# Altium schematic sheet Mosfet.SchDoc(Mosfet)
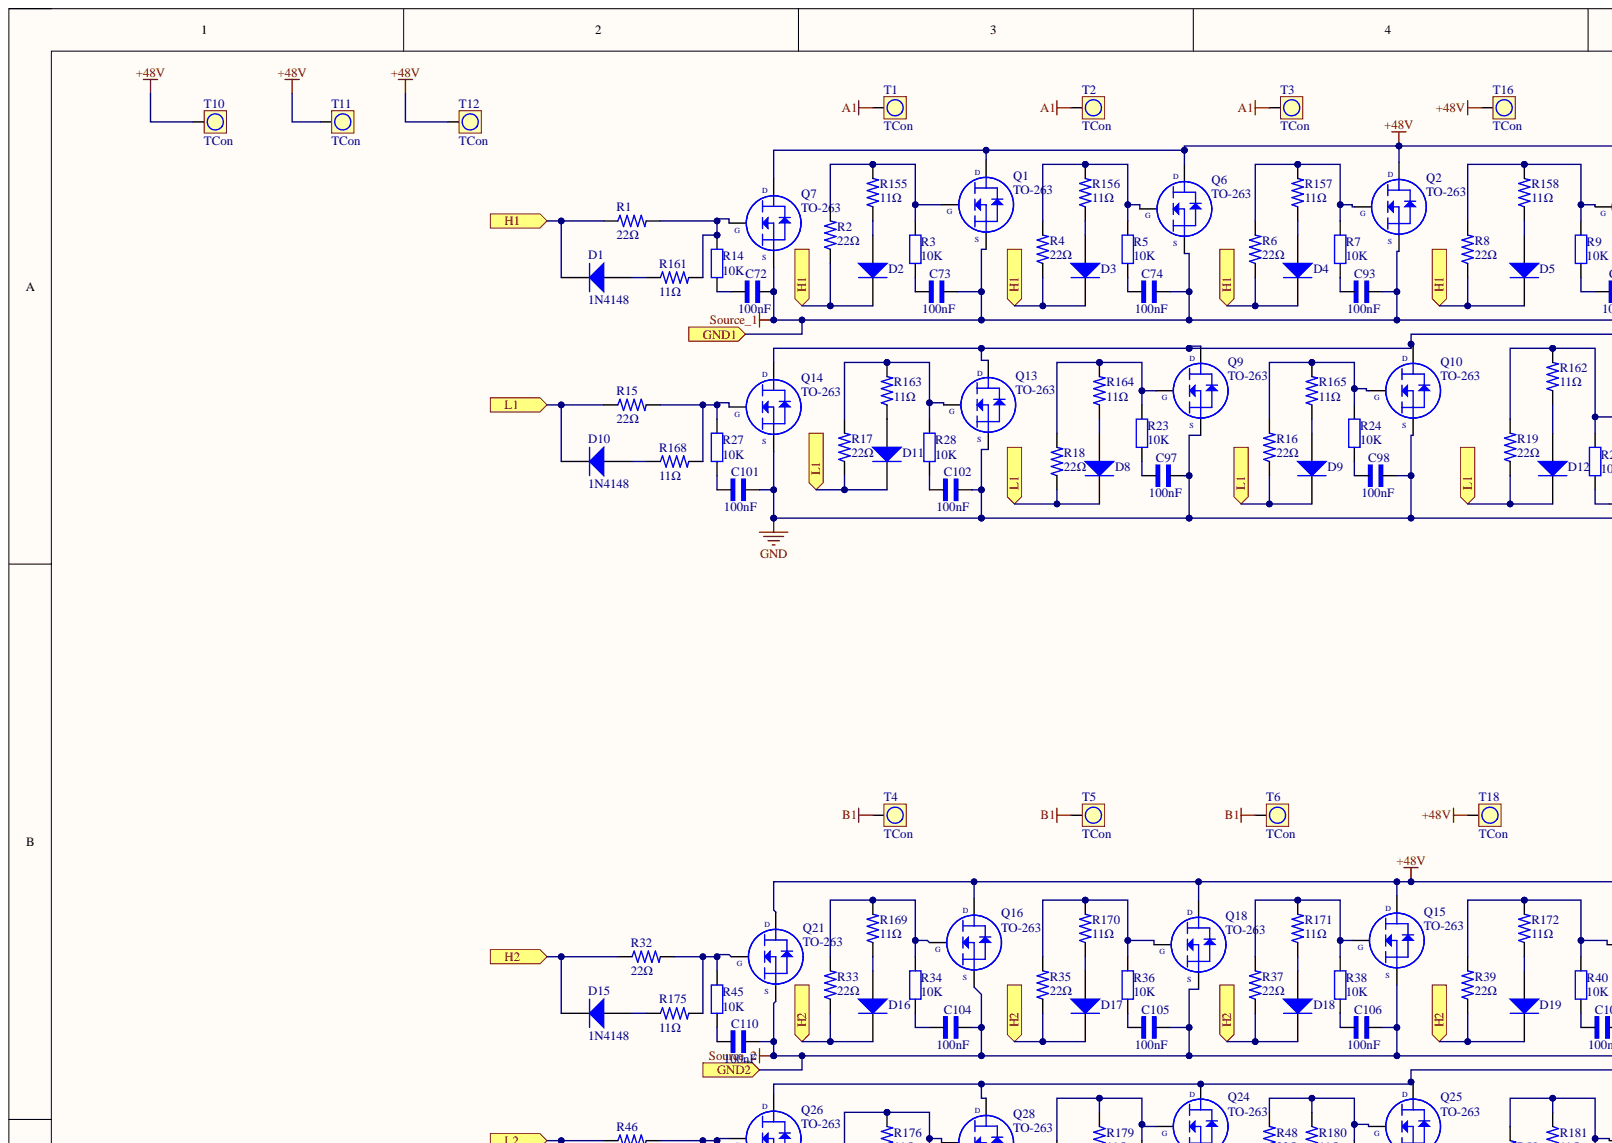
[diagram p0: Mosfet.SchDoc, top left]
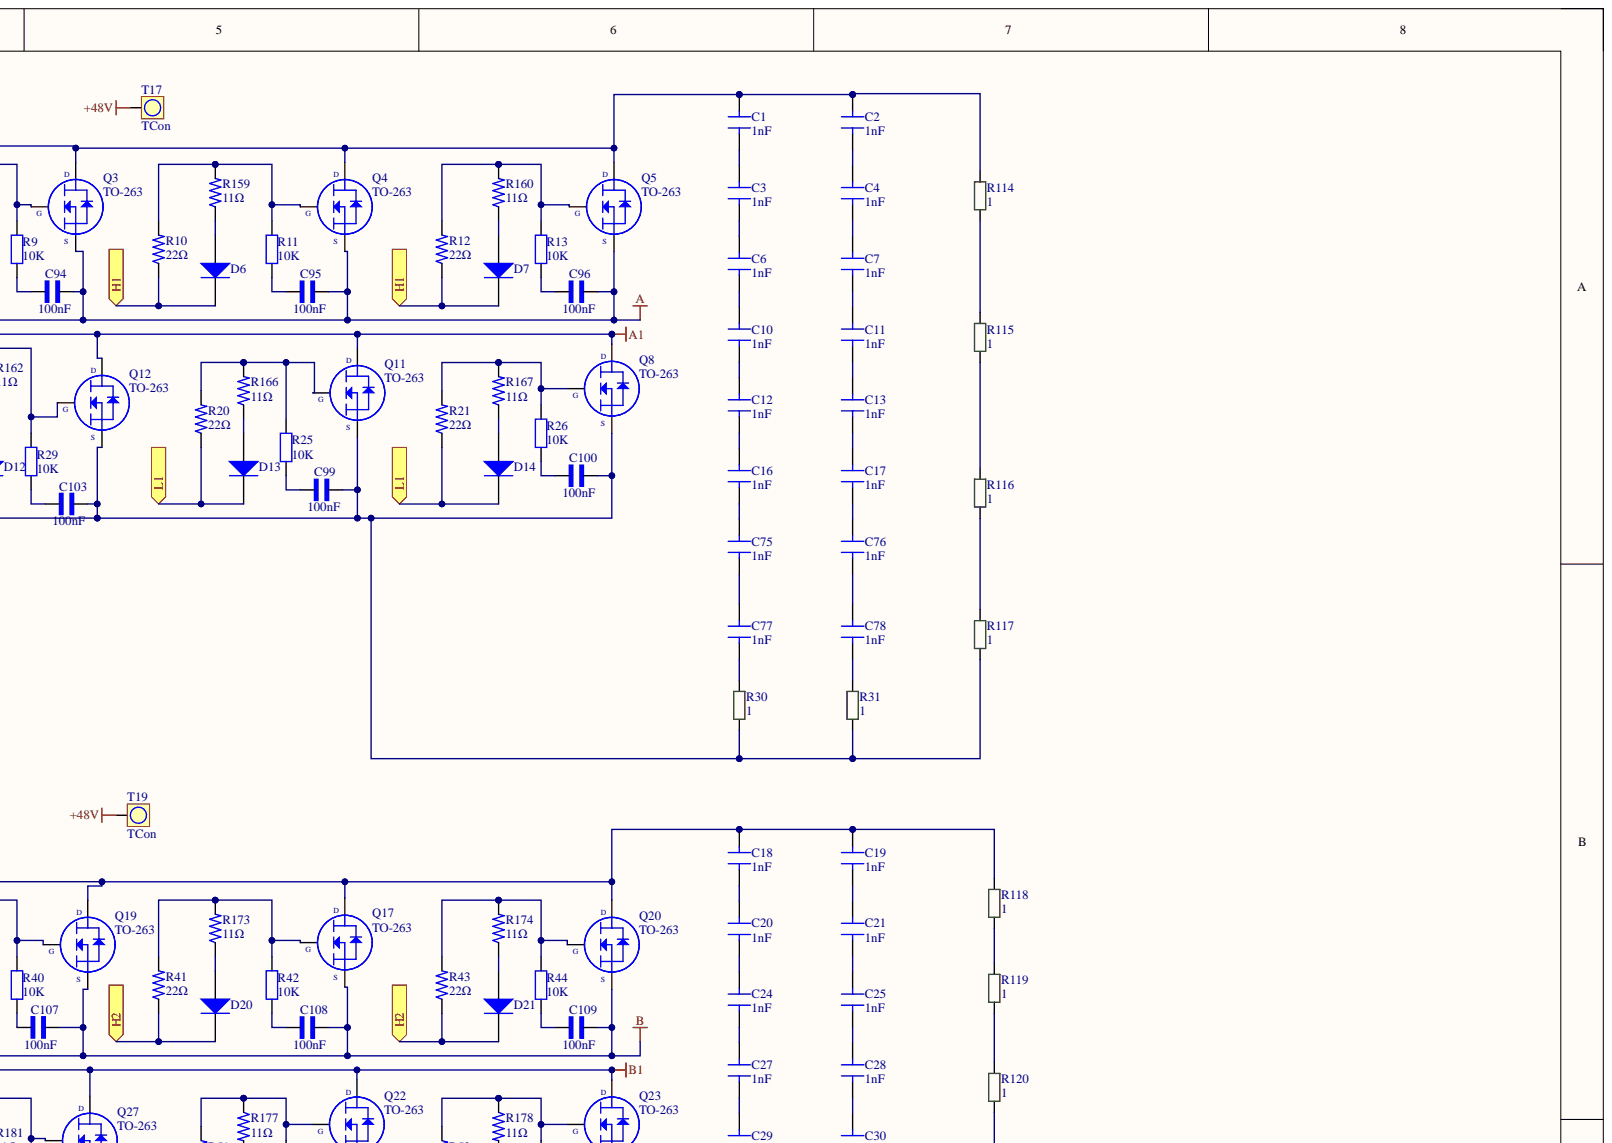
[diagram p1: Mosfet.SchDoc, top right]
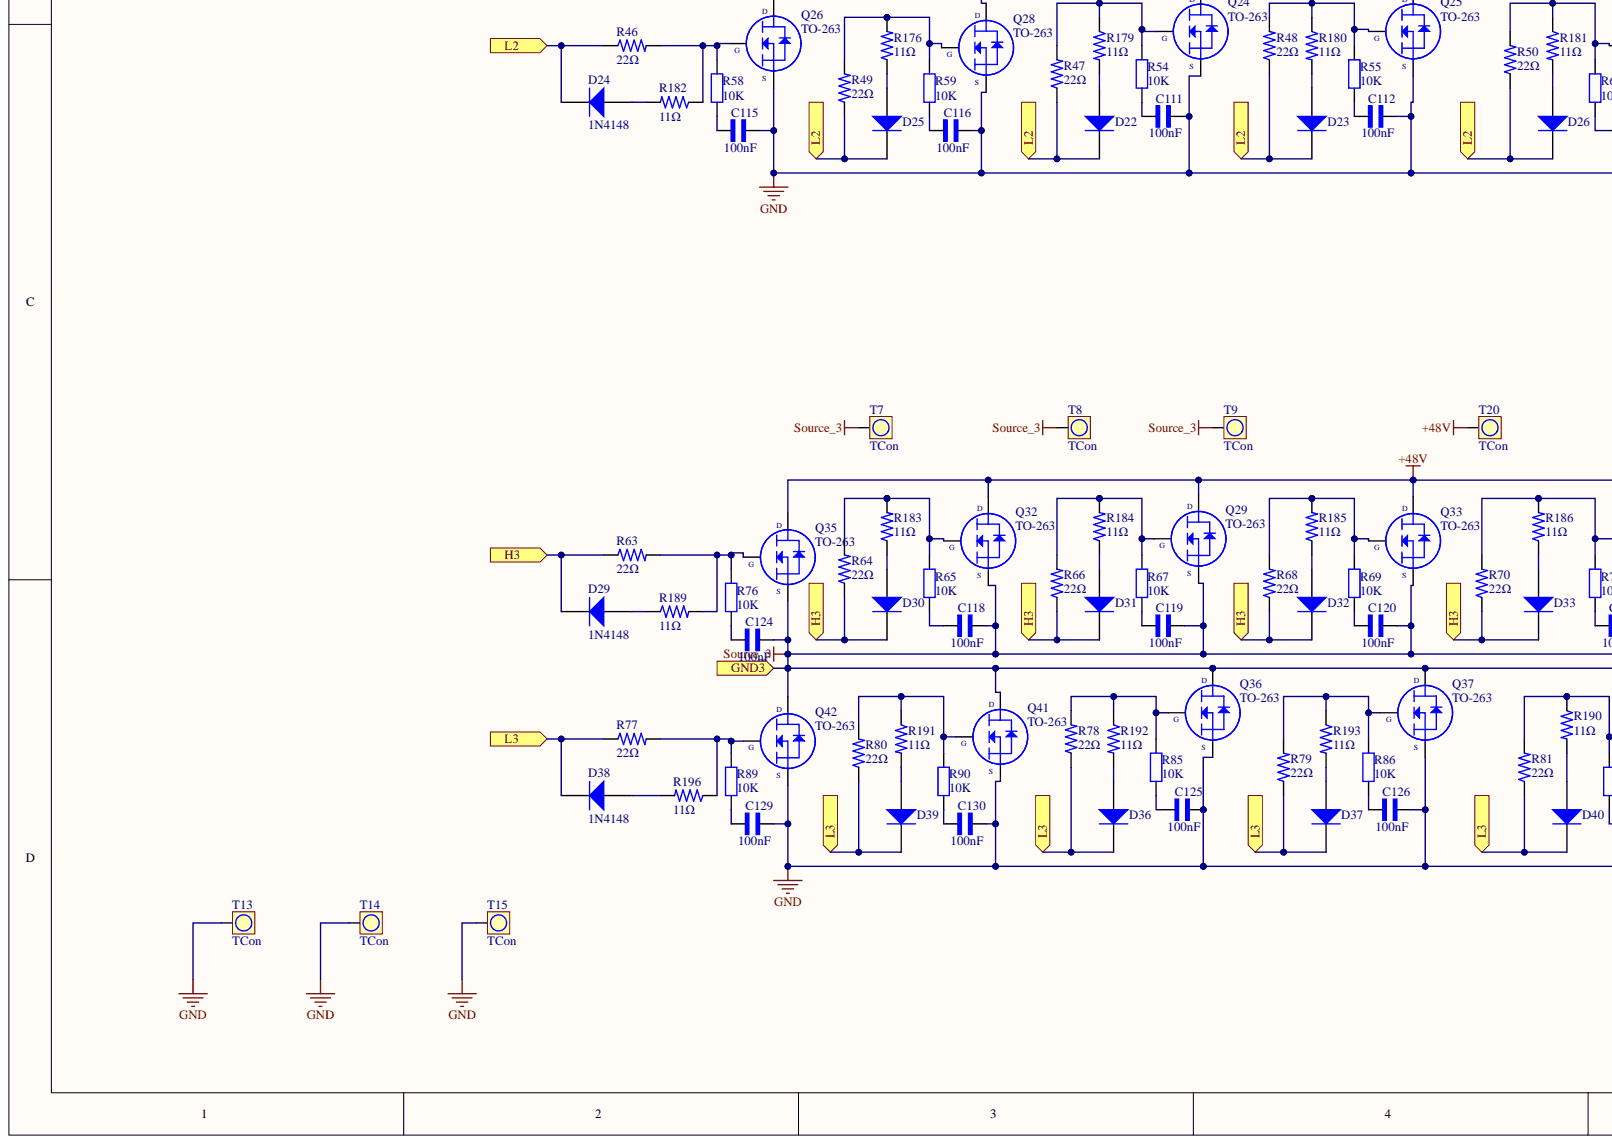
[diagram p2: Mosfet.SchDoc, bottom left]
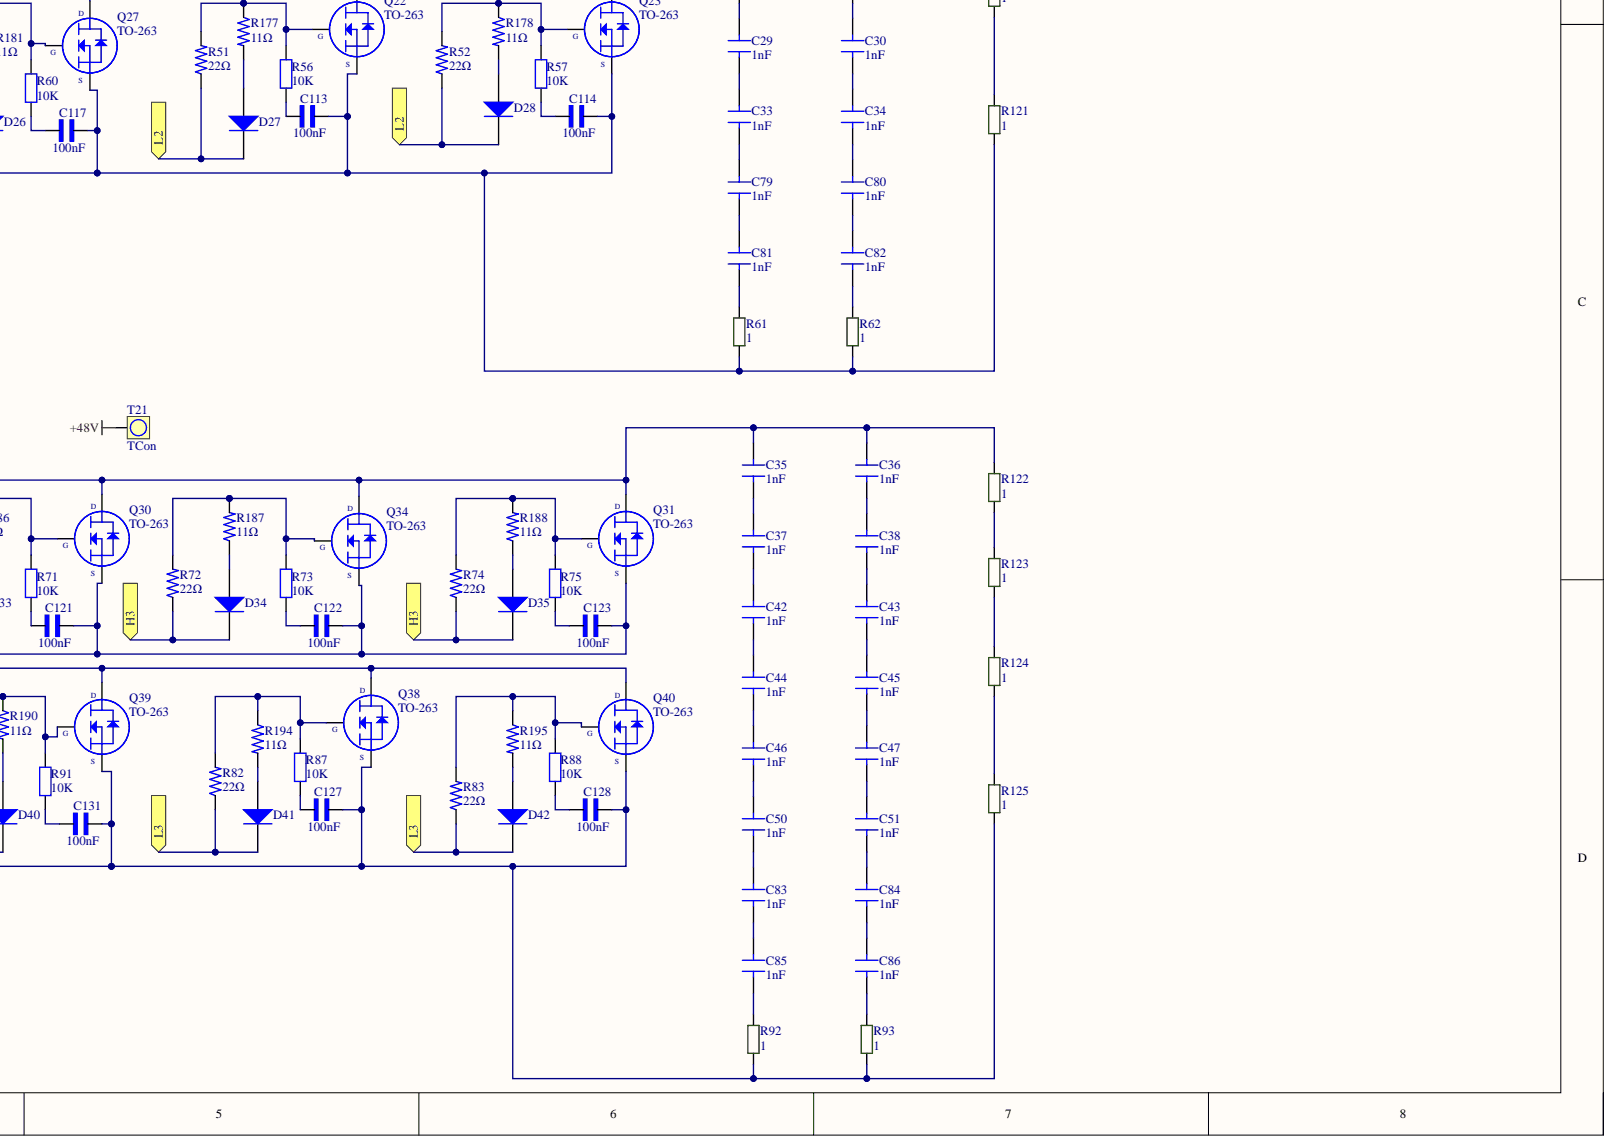
[diagram p3: Mosfet.SchDoc, bottom right]

## Parts
C1  1nF  [CAP 1206_3216 - 1.8MM]
C2  1nF  [CAP 1206_3216 - 1.8MM]
C3  1nF  [CAP 1206_3216 - 1.8MM]
C4  1nF  [CAP 1206_3216 - 1.8MM]
C6  1nF  [CAP 1206_3216 - 1.8MM]
C7  1nF  [CAP 1206_3216 - 1.8MM]
C10  1nF  [CAP 1206_3216 - 1.8MM]
C11  1nF  [CAP 1206_3216 - 1.8MM]
C12  1nF  [CAP 1206_3216 - 1.8MM]
C13  1nF  [CAP 1206_3216 - 1.8MM]
C16  1nF  [CAP 1206_3216 - 1.8MM]
C17  1nF  [CAP 1206_3216 - 1.8MM]
C18  1nF  [CAP 1206_3216 - 1.8MM]
C19  1nF  [CAP 1206_3216 - 1.8MM]
C20  1nF  [CAP 1206_3216 - 1.8MM]
C21  1nF  [CAP 1206_3216 - 1.8MM]
C24  1nF  [CAP 1206_3216 - 1.8MM]
C25  1nF  [CAP 1206_3216 - 1.8MM]
C27  1nF  [CAP 1206_3216 - 1.8MM]
C28  1nF  [CAP 1206_3216 - 1.8MM]
C29  1nF  [CAP 1206_3216 - 1.8MM]
C30  1nF  [CAP 1206_3216 - 1.8MM]
C33  1nF  [CAP 1206_3216 - 1.8MM]
C34  1nF  [CAP 1206_3216 - 1.8MM]
C35  1nF  [CAP 1206_3216 - 1.8MM]
C36  1nF  [CAP 1206_3216 - 1.8MM]
C37  1nF  [CAP 1206_3216 - 1.8MM]
C38  1nF  [CAP 1206_3216 - 1.8MM]
C42  1nF  [CAP 1206_3216 - 1.8MM]
C43  1nF  [CAP 1206_3216 - 1.8MM]
C44  1nF  [CAP 1206_3216 - 1.8MM]
C45  1nF  [CAP 1206_3216 - 1.8MM]
C46  1nF  [CAP 1206_3216 - 1.8MM]
C47  1nF  [CAP 1206_3216 - 1.8MM]
C50  1nF  [CAP 1206_3216 - 1.8MM]
C51  1nF  [CAP 1206_3216 - 1.8MM]
C72  100nF  [C-0805W]
C73  100nF  [C-0805W]
C74  100nF  [C-0805W]
C75  1nF  [CAP 1206_3216 - 1.8MM]
C76  1nF  [CAP 1206_3216 - 1.8MM]
C77  1nF  [CAP 1206_3216 - 1.8MM]
C78  1nF  [CAP 1206_3216 - 1.8MM]
C79  1nF  [CAP 1206_3216 - 1.8MM]
C80  1nF  [CAP 1206_3216 - 1.8MM]
C81  1nF  [CAP 1206_3216 - 1.8MM]
C82  1nF  [CAP 1206_3216 - 1.8MM]
C83  1nF  [CAP 1206_3216 - 1.8MM]
C84  1nF  [CAP 1206_3216 - 1.8MM]
C85  1nF  [CAP 1206_3216 - 1.8MM]
C86  1nF  [CAP 1206_3216 - 1.8MM]
C93  100nF  [C-0805W]
C94  100nF  [C-0805W]
C95  100nF  [C-0805W]
C96  100nF  [C-0805W]
C97  100nF  [C-0805W]
C98  100nF  [C-0805W]
C99  100nF  [C-0805W]
C100  100nF  [C-0805W]
C101  100nF  [C-0805W]
C102  100nF  [C-0805W]
C103  100nF  [C-0805W]
C104  100nF  [C-0805W]
C105  100nF  [C-0805W]
C106  100nF  [C-0805W]
C107  100nF  [C-0805W]
C108  100nF  [C-0805W]
C109  100nF  [C-0805W]
C110  100nF  [C-0805W]
C111  100nF  [C-0805W]
C112  100nF  [C-0805W]
C113  100nF  [C-0805W]
C114  100nF  [C-0805W]
C115  100nF  [C-0805W]
C116  100nF  [C-0805W]
C117  100nF  [C-0805W]
C118  100nF  [C-0805W]
C119  100nF  [C-0805W]
C120  100nF  [C-0805W]
C121  100nF  [C-0805W]
C122  100nF  [C-0805W]
C123  100nF  [C-0805W]
C124  100nF  [C-0805W]
C125  100nF  [C-0805W]
C126  100nF  [C-0805W]
C127  100nF  [C-0805W]
C128  100nF  [C-0805W]
C129  100nF  [C-0805W]
C130  100nF  [C-0805W]
C131  100nF  [C-0805W]
D1  1N4148 Diyot  [SOD-123]  (value not drawn)
D2  1N4148 Diyot  [SOD-123]  (value not drawn)
D3  1N4148 Diyot  [SOD-123]  (value not drawn)
D4  1N4148 Diyot  [SOD-123]  (value not drawn)
D5  1N4148 Diyot  [SOD-123]  (value not drawn)
D6  1N4148 Diyot  [SOD-123]  (value not drawn)
D7  1N4148 Diyot  [SOD-123]  (value not drawn)
D8  1N4148 Diyot  [SOD-123]  (value not drawn)
D9  1N4148 Diyot  [SOD-123]  (value not drawn)
D10  1N4148 Diyot  [SOD-123]  (value not drawn)
D11  1N4148 Diyot  [SOD-123]  (value not drawn)
D12  1N4148 Diyot  [SOD-123]  (value not drawn)
D13  1N4148 Diyot  [SOD-123]  (value not drawn)
D14  1N4148 Diyot  [SOD-123]  (value not drawn)
D15  1N4148 Diyot  [SOD-123]  (value not drawn)
D16  1N4148 Diyot  [SOD-123]  (value not drawn)
D17  1N4148 Diyot  [SOD-123]  (value not drawn)
D18  1N4148 Diyot  [SOD-123]  (value not drawn)
D19  1N4148 Diyot  [SOD-123]  (value not drawn)
D20  1N4148 Diyot  [SOD-123]  (value not drawn)
D21  1N4148 Diyot  [SOD-123]  (value not drawn)
D22  1N4148 Diyot  [SOD-123]  (value not drawn)
D23  1N4148 Diyot  [SOD-123]  (value not drawn)
D24  1N4148 Diyot  [SOD-123]  (value not drawn)
D25  1N4148 Diyot  [SOD-123]  (value not drawn)
D26  1N4148 Diyot  [SOD-123]  (value not drawn)
D27  1N4148 Diyot  [SOD-123]  (value not drawn)
D28  1N4148 Diyot  [SOD-123]  (value not drawn)
D29  1N4148 Diyot  [SOD-123]  (value not drawn)
D30  1N4148 Diyot  [SOD-123]  (value not drawn)
D31  1N4148 Diyot  [SOD-123]  (value not drawn)
D32  1N4148 Diyot  [SOD-123]  (value not drawn)
D33  1N4148 Diyot  [SOD-123]  (value not drawn)
D34  1N4148 Diyot  [SOD-123]  (value not drawn)
D35  1N4148 Diyot  [SOD-123]  (value not drawn)
D36  1N4148 Diyot  [SOD-123]  (value not drawn)
D37  1N4148 Diyot  [SOD-123]  (value not drawn)
D38  1N4148 Diyot  [SOD-123]  (value not drawn)
D39  1N4148 Diyot  [SOD-123]  (value not drawn)
D40  1N4148 Diyot  [SOD-123]  (value not drawn)
D41  1N4148 Diyot  [SOD-123]  (value not drawn)
D42  1N4148 Diyot  [SOD-123]  (value not drawn)
Q1  TO-263  [DPAK_TO263]
Q2  TO-263  [DPAK_TO263]
Q3  TO-263  [DPAK_TO263]
Q4  TO-263  [DPAK_TO263]
Q5  TO-263  [DPAK_TO263]
Q6  TO-263  [DPAK_TO263]
Q7  TO-263  [DPAK_TO263]
Q8  TO-263  [DPAK_TO263]
Q9  TO-263  [DPAK_TO263]
Q10  TO-263  [DPAK_TO263]
Q11  TO-263  [DPAK_TO263]
Q12  TO-263  [DPAK_TO263]
Q13  TO-263  [DPAK_TO263]
Q14  TO-263  [DPAK_TO263]
Q15  TO-263  [DPAK_TO263]
Q16  TO-263  [DPAK_TO263]
Q17  TO-263  [DPAK_TO263]
Q18  TO-263  [DPAK_TO263]
Q19  TO-263  [DPAK_TO263]
Q20  TO-263  [DPAK_TO263]
Q21  TO-263  [DPAK_TO263]
Q22  TO-263  [DPAK_TO263]
Q23  TO-263  [DPAK_TO263]
Q24  TO-263  [DPAK_TO263]
Q25  TO-263  [DPAK_TO263]
Q26  TO-263  [DPAK_TO263]
Q27  TO-263  [DPAK_TO263]
Q28  TO-263  [DPAK_TO263]
Q29  TO-263  [DPAK_TO263]
Q30  TO-263  [DPAK_TO263]
Q31  TO-263  [DPAK_TO263]
Q32  TO-263  [DPAK_TO263]
Q33  TO-263  [DPAK_TO263]
Q34  TO-263  [DPAK_TO263]
Q35  TO-263  [DPAK_TO263]
Q36  TO-263  [DPAK_TO263]
Q37  TO-263  [DPAK_TO263]
Q38  TO-263  [DPAK_TO263]
Q39  TO-263  [DPAK_TO263]
Q40  TO-263  [DPAK_TO263]
Q41  TO-263  [DPAK_TO263]
Q42  TO-263  [DPAK_TO263]
R1  22Ω  [R-1206W]
R2  22Ω  [R-1206W]
R3  Deger: 10K  [R-0805W]  (hidden comment: RES SMD 10K OHM 1% 1/8W 0805)
R4  22Ω  [R-1206W]
R5  Deger: 10K  [R-0805W]  (hidden comment: RES SMD 10K OHM 1% 1/8W 0805)
R6  22Ω  [R-1206W]
R7  Deger: 10K  [R-0805W]  (hidden comment: RES SMD 10K OHM 1% 1/8W 0805)
R8  22Ω  [R-1206W]
R9  Deger: 10K  [R-0805W]  (hidden comment: RES SMD 10K OHM 1% 1/8W 0805)
R10  22Ω  [R-1206W]
R11  Deger: 10K  [R-0805W]  (hidden comment: RES SMD 10K OHM 1% 1/8W 0805)
R12  22Ω  [R-1206W]
R13  Deger: 10K  [R-0805W]  (hidden comment: RES SMD 10K OHM 1% 1/8W 0805)
R14  Deger: 10K  [R-0805W]  (hidden comment: RES SMD 10K OHM 1% 1/8W 0805)
R15  22Ω  [R-1206W]
R16  22Ω  [R-1206W]
R17  22Ω  [R-1206W]
R18  22Ω  [R-1206W]
R19  22Ω  [R-1206W]
R20  22Ω  [R-1206W]
R21  22Ω  [R-1206W]
R23  Deger: 10K  [R-0805W]  (hidden comment: RES SMD 10K OHM 1% 1/8W 0805)
R24  Deger: 10K  [R-0805W]  (hidden comment: RES SMD 10K OHM 1% 1/8W 0805)
R25  Deger: 10K  [R-0805W]  (hidden comment: RES SMD 10K OHM 1% 1/8W 0805)
R26  Deger: 10K  [R-0805W]  (hidden comment: RES SMD 10K OHM 1% 1/8W 0805)
R27  Deger: 10K  [R-0805W]  (hidden comment: RES SMD 10K OHM 1% 1/8W 0805)
R28  Deger: 10K  [R-0805W]  (hidden comment: RES SMD 10K OHM 1% 1/8W 0805)
R29  Deger: 10K  [R-0805W]  (hidden comment: RES SMD 10K OHM 1% 1/8W 0805)
R30  1  [R-2512W]
R31  1  [R-2512W]
R32  22Ω  [R-1206W]
R33  22Ω  [R-1206W]
R34  Deger: 10K  [R-0805W]  (hidden comment: RES SMD 10K OHM 1% 1/8W 0805)
R35  22Ω  [R-1206W]
R36  Deger: 10K  [R-0805W]  (hidden comment: RES SMD 10K OHM 1% 1/8W 0805)
R37  22Ω  [R-1206W]
R38  Deger: 10K  [R-0805W]  (hidden comment: RES SMD 10K OHM 1% 1/8W 0805)
R39  22Ω  [R-1206W]
R40  Deger: 10K  [R-0805W]  (hidden comment: RES SMD 10K OHM 1% 1/8W 0805)
R41  22Ω  [R-1206W]
R42  Deger: 10K  [R-0805W]  (hidden comment: RES SMD 10K OHM 1% 1/8W 0805)
R43  22Ω  [R-1206W]
R44  Deger: 10K  [R-0805W]  (hidden comment: RES SMD 10K OHM 1% 1/8W 0805)
R45  Deger: 10K  [R-0805W]  (hidden comment: RES SMD 10K OHM 1% 1/8W 0805)
R46  22Ω  [R-1206W]
R47  22Ω  [R-1206W]
R48  22Ω  [R-1206W]
R49  22Ω  [R-1206W]
R50  22Ω  [R-1206W]
R51  22Ω  [R-1206W]
R52  22Ω  [R-1206W]
R54  Deger: 10K  [R-0805W]  (hidden comment: RES SMD 10K OHM 1% 1/8W 0805)
R55  Deger: 10K  [R-0805W]  (hidden comment: RES SMD 10K OHM 1% 1/8W 0805)
R56  Deger: 10K  [R-0805W]  (hidden comment: RES SMD 10K OHM 1% 1/8W 0805)
R57  Deger: 10K  [R-0805W]  (hidden comment: RES SMD 10K OHM 1% 1/8W 0805)
R58  Deger: 10K  [R-0805W]  (hidden comment: RES SMD 10K OHM 1% 1/8W 0805)
R59  Deger: 10K  [R-0805W]  (hidden comment: RES SMD 10K OHM 1% 1/8W 0805)
R60  Deger: 10K  [R-0805W]  (hidden comment: RES SMD 10K OHM 1% 1/8W 0805)
R61  1  [R-2512W]
R62  1  [R-2512W]
R63  22Ω  [R-1206W]
R64  22Ω  [R-1206W]
R65  Deger: 10K  [R-0805W]  (hidden comment: RES SMD 10K OHM 1% 1/8W 0805)
R66  22Ω  [R-1206W]
R67  Deger: 10K  [R-0805W]  (hidden comment: RES SMD 10K OHM 1% 1/8W 0805)
R68  22Ω  [R-1206W]
R69  Deger: 10K  [R-0805W]  (hidden comment: RES SMD 10K OHM 1% 1/8W 0805)
R70  22Ω  [R-1206W]
R71  Deger: 10K  [R-0805W]  (hidden comment: RES SMD 10K OHM 1% 1/8W 0805)
R72  22Ω  [R-1206W]
R73  Deger: 10K  [R-0805W]  (hidden comment: RES SMD 10K OHM 1% 1/8W 0805)
R74  22Ω  [R-1206W]
R75  Deger: 10K  [R-0805W]  (hidden comment: RES SMD 10K OHM 1% 1/8W 0805)
R76  Deger: 10K  [R-0805W]  (hidden comment: RES SMD 10K OHM 1% 1/8W 0805)
R77  22Ω  [R-1206W]
R78  22Ω  [R-1206W]
R79  22Ω  [R-1206W]
R80  22Ω  [R-1206W]
R81  22Ω  [R-1206W]
R82  22Ω  [R-1206W]
R83  22Ω  [R-1206W]
R85  Deger: 10K  [R-0805W]  (hidden comment: RES SMD 10K OHM 1% 1/8W 0805)
R86  Deger: 10K  [R-0805W]  (hidden comment: RES SMD 10K OHM 1% 1/8W 0805)
R87  Deger: 10K  [R-0805W]  (hidden comment: RES SMD 10K OHM 1% 1/8W 0805)
R88  Deger: 10K  [R-0805W]  (hidden comment: RES SMD 10K OHM 1% 1/8W 0805)
R89  Deger: 10K  [R-0805W]  (hidden comment: RES SMD 10K OHM 1% 1/8W 0805)
R90  Deger: 10K  [R-0805W]  (hidden comment: RES SMD 10K OHM 1% 1/8W 0805)
R91  Deger: 10K  [R-0805W]  (hidden comment: RES SMD 10K OHM 1% 1/8W 0805)
R92  1  [R-2512W]
R93  1  [R-2512W]
R114  1  [R-2512W]
R115  1  [R-2512W]
R116  1  [R-2512W]
R117  1  [R-2512W]
R118  1  [R-2512W]
R119  1  [R-2512W]
R120  1  [R-2512W]
R121  1  [R-2512W]
R122  1  [R-2512W]
R123  1  [R-2512W]
R124  1  [R-2512W]
R125  1  [R-2512W]
R155  11Ω  [R-1206W]
R156  11Ω  [R-1206W]
R157  11Ω  [R-1206W]
R158  11Ω  [R-1206W]
R159  11Ω  [R-1206W]
R160  11Ω  [R-1206W]
R161  11Ω  [R-1206W]
R162  11Ω  [R-1206W]
R163  11Ω  [R-1206W]
R164  11Ω  [R-1206W]
R165  11Ω  [R-1206W]
R166  11Ω  [R-1206W]
R167  11Ω  [R-1206W]
R168  11Ω  [R-1206W]
R169  11Ω  [R-1206W]
R170  11Ω  [R-1206W]
R171  11Ω  [R-1206W]
R172  11Ω  [R-1206W]
R173  11Ω  [R-1206W]
R174  11Ω  [R-1206W]
R175  11Ω  [R-1206W]
R176  11Ω  [R-1206W]
R177  11Ω  [R-1206W]
R178  11Ω  [R-1206W]
R179  11Ω  [R-1206W]
R180  11Ω  [R-1206W]
R181  11Ω  [R-1206W]
R182  11Ω  [R-1206W]
R183  11Ω  [R-1206W]
R184  11Ω  [R-1206W]
R185  11Ω  [R-1206W]
R186  11Ω  [R-1206W]
R187  11Ω  [R-1206W]
R188  11Ω  [R-1206W]
R189  11Ω  [R-1206W]
R190  11Ω  [R-1206W]
R191  11Ω  [R-1206W]
R192  11Ω  [R-1206W]
R193  11Ω  [R-1206W]
R194  11Ω  [R-1206W]
R195  11Ω  [R-1206W]
R196  11Ω  [R-1206W]
T1  TCon  [connectorout]
T2  TCon  [connectorout]
T3  TCon  [connectorout]
T4  TCon  [connectorout]
T5  TCon  [connectorout]
T6  TCon  [connectorout]
T7  TCon  [connectorout]
T8  TCon  [connectorout]
T9  TCon  [connectorout]
T10  TCon  [connectorout]
T11  TCon  [connectorout]
T12  TCon  [connectorout]
T13  TCon  [connectorout]
T14  TCon  [connectorout]
T15  TCon  [connectorout]
T16  TCon  [connectorout]
T17  TCon  [connectorout]
T18  TCon  [connectorout]
T19  TCon  [connectorout]
T20  TCon  [connectorout]
T21  TCon  [connectorout]

## Ports
GND1, GND2, GND3, H1, H2, H3, L1, L2, L3

## Nets
+48V: C1.2 C2.2 C18.2 C19.2 C35.2 C36.2 Q1.3 Q2.3 Q3.3 Q4.3 Q5.3 Q6.3 Q7.3 Q15.3 Q16.3 Q17.3 Q18.3 Q19.3 Q20.3 Q21.3 Q29.3 Q30.3 Q31.3 Q32.3 Q33.3 Q34.3 Q35.3 R114.2 R118.2 R122.2 T10.1 T11.1 T12.1 T16.1 T17.1 T18.1 T19.1 T20.1 T21.1
A1: Q8.3 Q9.3 Q10.3 Q11.3 Q12.3 Q13.3 Q14.3 T1.1 T2.1 T3.1
B1: Q22.3 Q23.3 Q24.3 Q25.3 Q26.3 Q27.3 Q28.3 T4.1 T5.1 T6.1
GND: C97.1 C98.1 C99.1 C100.1 C101.1 C102.1 C103.1 C111.1 C112.1 C113.1 C114.1 C115.1 C116.1 C117.1 C125.1 C126.1 C127.1 C128.1 C129.1 C130.1 C131.1 Q8.2 Q9.2 Q10.2 Q11.2 Q12.2 Q13.2 Q14.2 Q22.2 Q23.2 Q24.2 Q25.2 Q26.2 Q27.2 Q28.2 Q36.2 Q37.2 Q38.2 Q39.2 Q40.2 Q41.2 Q42.2 R30.1 R31.1 R61.1 R62.1 R92.1 R93.1 R117.1 R121.1 R125.1 T13.1 T14.1 T15.1
A: C72.1 C73.1 C74.1 C93.1 C94.1 C95.1 C96.1 Q1.2 Q2.2 Q3.2 Q4.2 Q5.2 Q6.2 Q7.2 ; port GND1
B: C104.1 C105.1 C106.1 C107.1 C108.1 C109.1 C110.1 Q15.2 Q16.2 Q17.2 Q18.2 Q19.2 Q20.2 Q21.2 ; port GND2
H1: D1.2 D2.2 D3.2 D4.2 D5.2 D6.2 D7.2 R1.1 R2.1 R4.1 R6.1 R8.1 R10.1 R12.1 ; port H1
H2: D15.2 D16.2 D17.2 D18.2 D19.2 D20.2 D21.2 R32.1 R33.1 R35.1 R37.1 R39.1 R41.1 R43.1 ; port H2
H3: D29.2 D30.2 D31.2 D32.2 D33.2 D34.2 D35.2 R63.1 R64.1 R66.1 R68.1 R70.1 R72.1 R74.1 ; port H3
L1: D8.2 D9.2 D10.2 D11.2 D12.2 D13.2 D14.2 R15.1 R16.1 R17.1 R18.1 R19.1 R20.1 R21.1 ; port L1
L2: D22.2 D23.2 D24.2 D25.2 D26.2 D27.2 D28.2 R46.1 R47.1 R48.1 R49.1 R50.1 R51.1 R52.1 ; port L2
L3: D36.2 D37.2 D38.2 D39.2 D40.2 D41.2 D42.2 R77.1 R78.1 R79.1 R80.1 R81.1 R82.1 R83.1 ; port L3
Source_3: C118.1 C119.1 C120.1 C121.1 C122.1 C123.1 C124.1 Q29.2 Q30.2 Q31.2 Q32.2 Q33.2 Q34.2 Q35.2 Q36.3 Q37.3 Q38.3 Q39.3 Q40.3 Q41.3 Q42.3 T7.1 T8.1 T9.1 ; port GND3
NetC1_1: C1.1 C3.2
NetC2_1: C2.1 C4.2
NetC3_1: C3.1 C6.2
NetC4_1: C4.1 C7.2
NetC6_1: C6.1 C10.2
NetC7_1: C7.1 C11.2
NetC10_1: C10.1 C12.2
NetC11_1: C11.1 C13.2
NetC12_1: C12.1 C16.2
NetC13_1: C13.1 C17.2
NetC16_1: C16.1 C75.2
NetC17_1: C17.1 C76.2
NetC18_1: C18.1 C20.2
NetC19_1: C19.1 C21.2
NetC20_1: C20.1 C24.2
NetC21_1: C21.1 C25.2
NetC24_1: C24.1 C27.2
NetC25_1: C25.1 C28.2
NetC27_1: C27.1 C29.2
NetC28_1: C28.1 C30.2
NetC29_1: C29.1 C33.2
NetC30_1: C30.1 C34.2
NetC33_1: C33.1 C79.2
NetC34_1: C34.1 C80.2
NetC35_1: C35.1 C37.2
NetC36_1: C36.1 C38.2
NetC37_1: C37.1 C42.2
NetC38_1: C38.1 C43.2
NetC42_1: C42.1 C44.2
NetC43_1: C43.1 C45.2
NetC44_1: C44.1 C46.2
NetC45_1: C45.1 C47.2
NetC46_1: C46.1 C50.2
NetC47_1: C47.1 C51.2
NetC50_1: C50.1 C83.2
NetC51_1: C51.1 C84.2
NetC72_2: C72.2 R14.1
NetC73_2: C73.2 R3.1
NetC74_2: C74.2 R5.1
NetC75_1: C75.1 C77.2
NetC76_1: C76.1 C78.2
NetC77_1: C77.1 R30.2
NetC78_1: C78.1 R31.2
NetC79_1: C79.1 C81.2
NetC80_1: C80.1 C82.2
NetC81_1: C81.1 R61.2
NetC82_1: C82.1 R62.2
NetC83_1: C83.1 C85.2
NetC84_1: C84.1 C86.2
NetC85_1: C85.1 R92.2
NetC86_1: C86.1 R93.2
NetC93_2: C93.2 R7.1
NetC94_2: C94.2 R9.1
NetC95_2: C95.2 R11.1
NetC96_2: C96.2 R13.1
NetC97_2: C97.2 R23.1
NetC98_2: C98.2 R24.1
NetC99_2: C99.2 R25.1
NetC100_2: C100.2 R26.1
NetC101_2: C101.2 R27.1
NetC102_2: C102.2 R28.1
NetC103_2: C103.2 R29.1
NetC104_2: C104.2 R34.1
NetC105_2: C105.2 R36.1
NetC106_2: C106.2 R38.1
NetC107_2: C107.2 R40.1
NetC108_2: C108.2 R42.1
NetC109_2: C109.2 R44.1
NetC110_2: C110.2 R45.1
NetC111_2: C111.2 R54.1
NetC112_2: C112.2 R55.1
NetC113_2: C113.2 R56.1
NetC114_2: C114.2 R57.1
NetC115_2: C115.2 R58.1
NetC116_2: C116.2 R59.1
NetC117_2: C117.2 R60.1
NetC118_2: C118.2 R65.1
NetC119_2: C119.2 R67.1
NetC120_2: C120.2 R69.1
NetC121_2: C121.2 R71.1
NetC122_2: C122.2 R73.1
NetC123_2: C123.2 R75.1
NetC124_2: C124.2 R76.1
NetC125_2: C125.2 R85.1
NetC126_2: C126.2 R86.1
NetC127_2: C127.2 R87.1
NetC128_2: C128.2 R88.1
NetC129_2: C129.2 R89.1
NetC130_2: C130.2 R90.1
NetC131_2: C131.2 R91.1
NetD1_1: D1.1 R161.1
NetD2_1: D2.1 R155.1
NetD3_1: D3.1 R156.1
NetD4_1: D4.1 R157.1
NetD5_1: D5.1 R158.1
NetD6_1: D6.1 R159.1
NetD7_1: D7.1 R160.1
NetD8_1: D8.1 R164.1
NetD9_1: D9.1 R165.1
NetD10_1: D10.1 R168.1
NetD11_1: D11.1 R163.1
NetD12_1: D12.1 R162.1
NetD13_1: D13.1 R166.1
NetD14_1: D14.1 R167.1
NetD15_1: D15.1 R175.1
NetD16_1: D16.1 R169.1
NetD17_1: D17.1 R170.1
NetD18_1: D18.1 R171.1
NetD19_1: D19.1 R172.1
NetD20_1: D20.1 R173.1
NetD21_1: D21.1 R174.1
NetD22_1: D22.1 R179.2
NetD23_1: D23.1 R180.2
NetD24_1: D24.1 R182.2
NetD25_1: D25.1 R176.1
NetD26_1: D26.1 R181.2
NetD27_1: D27.1 R177.2
NetD28_1: D28.1 R178.2
NetD29_1: D29.1 R189.1
NetD30_1: D30.1 R183.1
NetD31_1: D31.1 R184.1
NetD32_1: D32.1 R185.1
NetD33_1: D33.1 R186.1
NetD34_1: D34.1 R187.1
NetD35_1: D35.1 R188.1
NetD36_1: D36.1 R192.2
NetD37_1: D37.1 R193.2
NetD38_1: D38.1 R196.2
NetD39_1: D39.1 R191.2
NetD40_1: D40.1 R190.2
NetD41_1: D41.1 R194.2
NetD42_1: D42.1 R195.2
NetQ1_1: Q1.1 R2.2 R3.2 R155.2
NetQ2_1: Q2.1 R6.2 R7.2 R157.2
NetQ3_1: Q3.1 R8.2 R9.2 R158.2
NetQ4_1: Q4.1 R10.2 R11.2 R159.2
NetQ5_1: Q5.1 R12.2 R13.2 R160.2
NetQ6_1: Q6.1 R4.2 R5.2 R156.2
NetQ7_1: Q7.1 R1.2 R14.2 R161.2
NetQ8_1: Q8.1 R21.2 R26.2 R167.2
NetQ9_1: Q9.1 R18.2 R23.2 R164.2
NetQ10_1: Q10.1 R16.2 R24.2 R165.2
NetQ11_1: Q11.1 R20.2 R25.2 R166.2
NetQ12_1: Q12.1 R19.2 R29.2 R162.2
NetQ13_1: Q13.1 R17.2 R28.2 R163.2
NetQ14_1: Q14.1 R15.2 R27.2 R168.2
NetQ15_1: Q15.1 R37.2 R38.2 R171.2
NetQ16_1: Q16.1 R33.2 R34.2 R169.2
NetQ17_1: Q17.1 R41.2 R42.2 R173.2
NetQ18_1: Q18.1 R35.2 R36.2 R170.2
NetQ19_1: Q19.1 R39.2 R40.2 R172.2
NetQ20_1: Q20.1 R43.2 R44.2 R174.2
NetQ21_1: Q21.1 R32.2 R45.2 R175.2
NetQ22_1: Q22.1 R51.2 R56.2 R177.1
NetQ23_1: Q23.1 R52.2 R57.2 R178.1
NetQ24_1: Q24.1 R47.2 R54.2 R179.1
NetQ25_1: Q25.1 R48.2 R55.2 R180.1
NetQ26_1: Q26.1 R46.2 R58.2 R182.1
NetQ27_1: Q27.1 R50.2 R60.2 R181.1
NetQ28_1: Q28.1 R49.2 R59.2 R176.2
NetQ29_1: Q29.1 R66.2 R67.2 R184.2
NetQ30_1: Q30.1 R70.2 R71.2 R186.2
NetQ31_1: Q31.1 R74.2 R75.2 R188.2
NetQ32_1: Q32.1 R64.2 R65.2 R183.2
NetQ33_1: Q33.1 R68.2 R69.2 R185.2
NetQ34_1: Q34.1 R72.2 R73.2 R187.2
NetQ35_1: Q35.1 R63.2 R76.2 R189.2
NetQ36_1: Q36.1 R78.2 R85.2 R192.1
NetQ37_1: Q37.1 R79.2 R86.2 R193.1
NetQ38_1: Q38.1 R82.2 R87.2 R194.1
NetQ39_1: Q39.1 R81.2 R91.2 R190.1
NetQ40_1: Q40.1 R83.2 R88.2 R195.1
NetQ41_1: Q41.1 R80.2 R90.2 R191.1
NetQ42_1: Q42.1 R77.2 R89.2 R196.1
NetR114_1: R114.1 R115.2
NetR115_1: R115.1 R116.2
NetR116_1: R116.1 R117.2
NetR118_1: R118.1 R119.2
NetR119_1: R119.1 R120.2
NetR120_1: R120.1 R121.2
NetR122_1: R122.1 R123.2
NetR123_1: R123.1 R124.2
NetR124_1: R124.1 R125.2

## Library data of the parts
C1-C4 C6 C7 C10-C13 C16-C21 C24 C25 C27-C30 C33-C38 C42-C47 C50 C51 C75-C86 = CAP 1nF 1206: Component Kind: Standard; Library Name: PROJE.SchLib
C72-C74 C93-C131 = CAP 100nF 50V SMT 0805: WCAP-CSGP General Purpose MLCC; SMT 0805; X7R; 100nF 10%; 50V; -55C to +125C; Tech. PN X7R0805104K050DFCT10000; MF: Wurth Electronics Inc; MP: 885012207098; Availability: Warning; BuyPart: https://www.snapeda.com/parts/885012207098/Wurth%20Electronics%20Inc/view-part/4217223/?ref=eda; Component Kind: Standard; DigiKey-Purchase-URL: https://snapeda.com/shop?store=DigiKey&id=4217223; Mouser-Purchase-URL: https://snapeda.com/shop?store=Mouser&id=4217223; Package: 0805 Wurth Electronics; Price: None; SnapEDA_Link: https://www.snapeda.com/parts/885012207098/Wurth%20Electronics%20Inc/view-part/4217223/?ref=snap; Library Name: PROJE.SchLib
D1-D42 = 1N4148: DIODE GEN PURP 100V 150MA SOD123; +Sicaklik: +150; -Sicaklik: -65; Lehim Yöntemi: SMD; Uretici Kodu: 1N4148W-TP; Cins: Diyot; Component Kind: Standard; Deger: 1N4148; Kılıf: SOD123; MSL: 1 (Unlimited); Library Name: PROJE.SchLib
R1 R2 R4 R6 R8 R10 R12 R15-R21 R32 R33 R35 R37 R39 R41 R43 R46-R52 R63 R64 R66 R68 R70 R72 R74 R77-R83 = RES 22Ω 1206: CRG1206 1% 22R; MP: 1623463-1; Availability: Unavailable; Component Kind: Standard; Package: None; Price: None; Library Name: PROJE.SchLib
R3 R5 R7 R9 R11 R13 R14 R23-R29 R34 R36 R38 R40 R42 R44 R45 R54-R60 R65 R67 R69 R71 R73 R75 R76 R85-R91 = 10K 0805: 10 kOhms ±1% 0.125W, 1/8W Chip Resistor 0805 (2012 Metric) Moisture Resistant Thick Film; +Sicaklik: +155; -Sicaklik: -55; Cins: Direnc; Kılıf: 0805; Uretici Kodu: RC0805FR-0710KL; Component Kind: Standard; Lehim Yöntemi: SMD; MSL: 1 (Unlimited); Library Name: PROJE.SchLib
R30 R31 R61 R62 R92 R93 R114-R125 = 0207 melf: Component Kind: Standard; ComponentLink1Description: https://www.ozdisan.com/pasif-komponentler/direncler/smt-smd-direncler/M271UFG100KT20; ComponentLink1URL: https://www.ozdisan.com/pasif-komponentler/direncler/smt-smd-direncler/M271UFG100KT20; Library Name: PROJE.SchLib
R155-R196 = RES 11Ω 1206: CRG1206 1% 11R; MP: 1623463-1; Availability: Unavailable; Component Kind: Standard; Package: None; Price: None; Library Name: PROJE.SchLib
T1-T21 = Terminal Connector: Component Kind: Standard; ComponentLink1Description: https://www.ozdisan.com/konnektor-baglanti-elemanlari/terminal-konnektorler/vida-tipi-konnektorler/K36-00AH; ComponentLink1URL: https://www.ozdisan.com/konnektor-baglanti-elemanlari/terminal-konnektorler/vida-tipi-konnektorler/K36-00AH; Library Name: PROJE.SchLib
Q1-Q42 = TO-263: Component Kind: Standard; Library Name: PROJE.SchLib
